# Altium schematic sheet 遥控.SchDoc
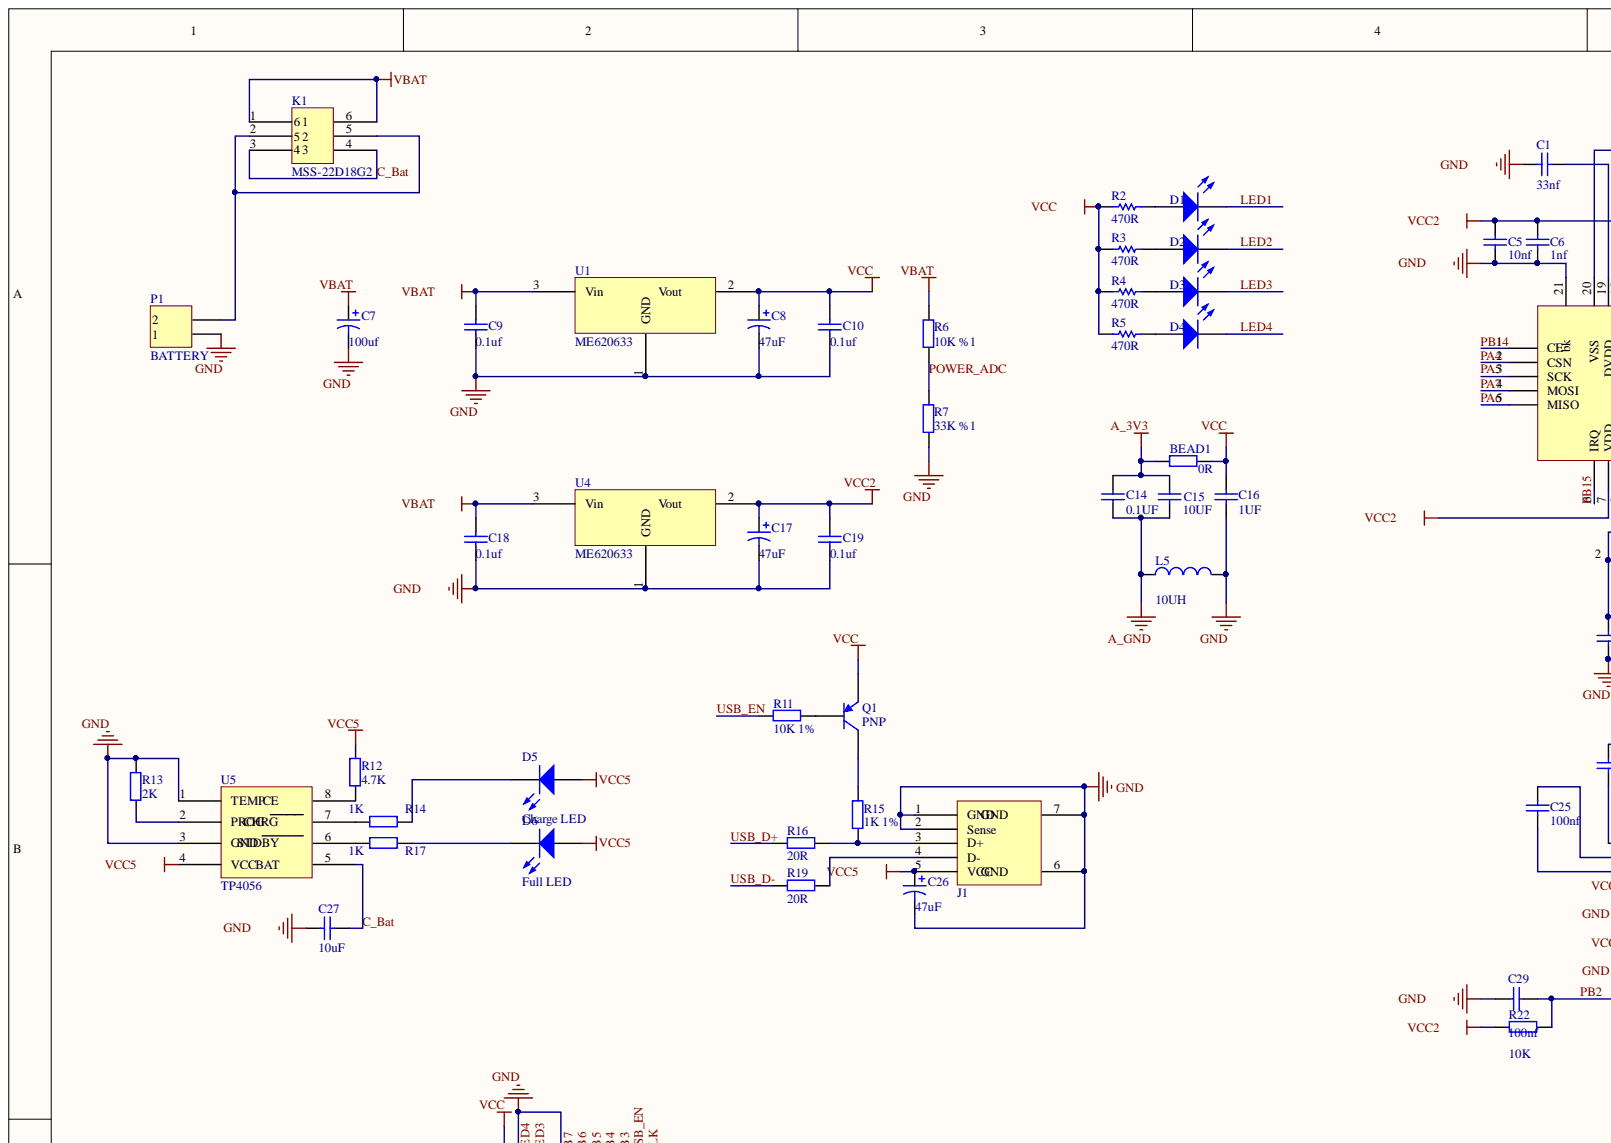
[diagram p0: 遥控.SchDoc, top left]
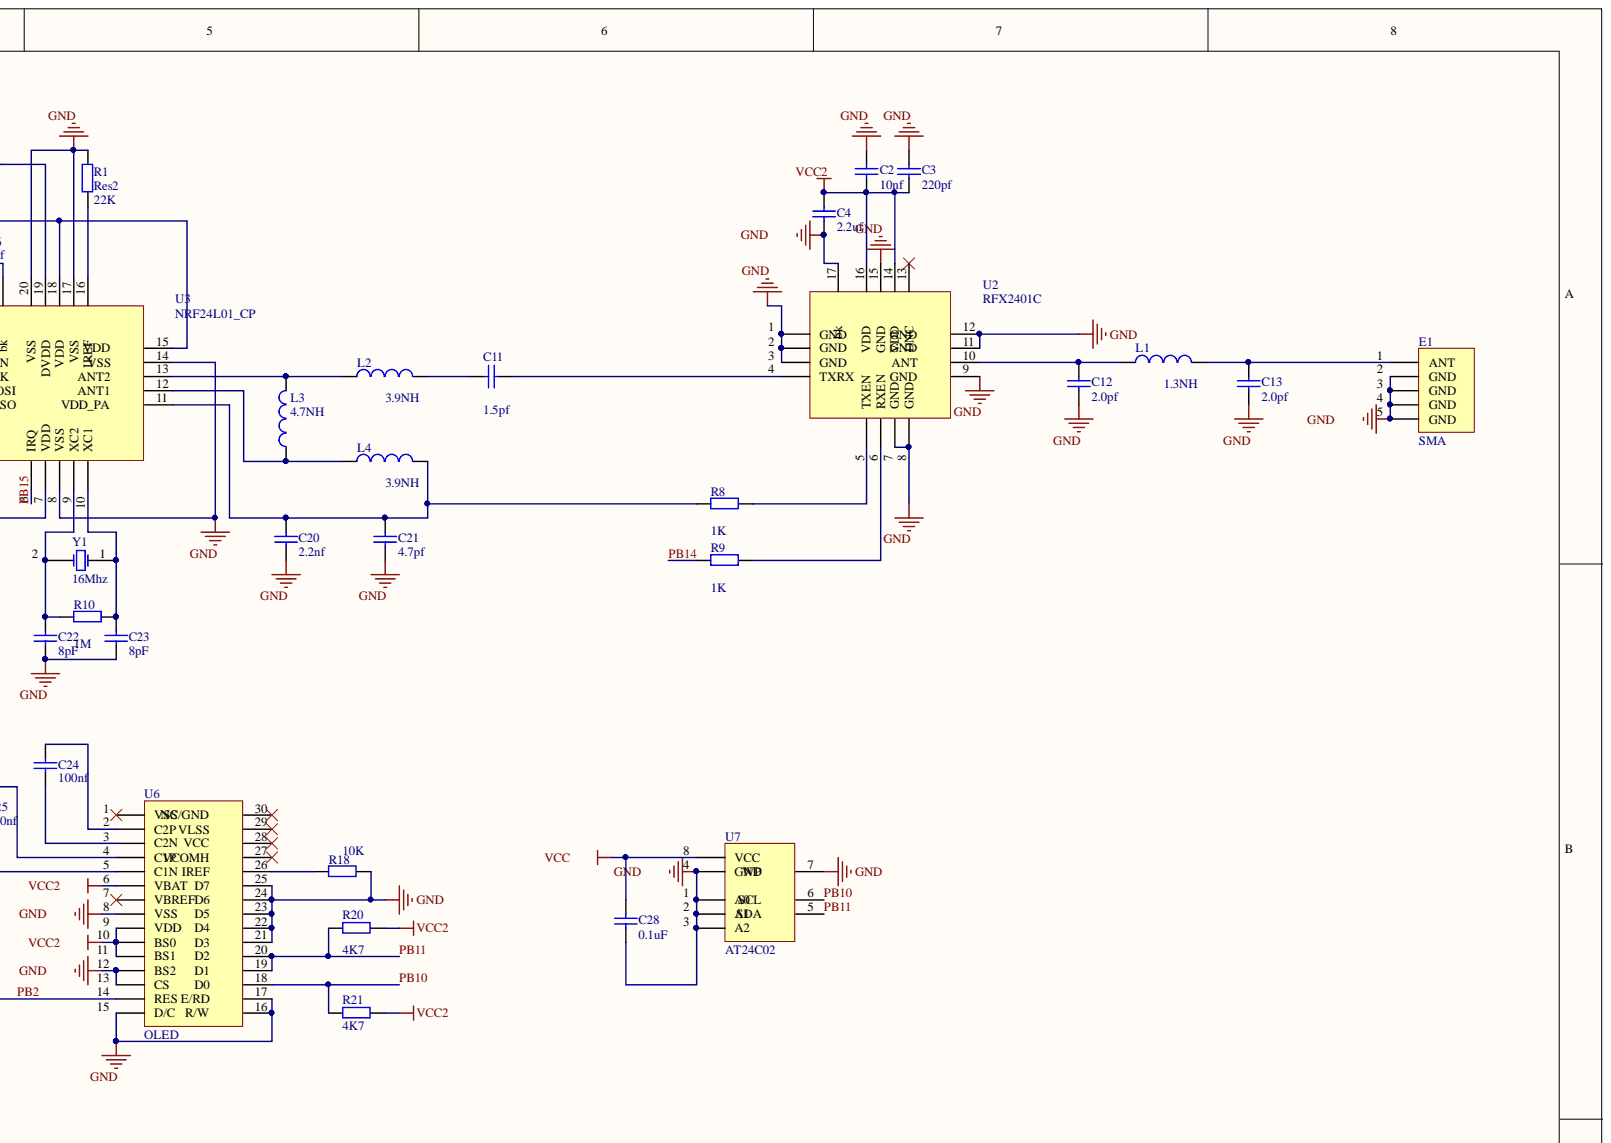
[diagram p1: 遥控.SchDoc, top right]
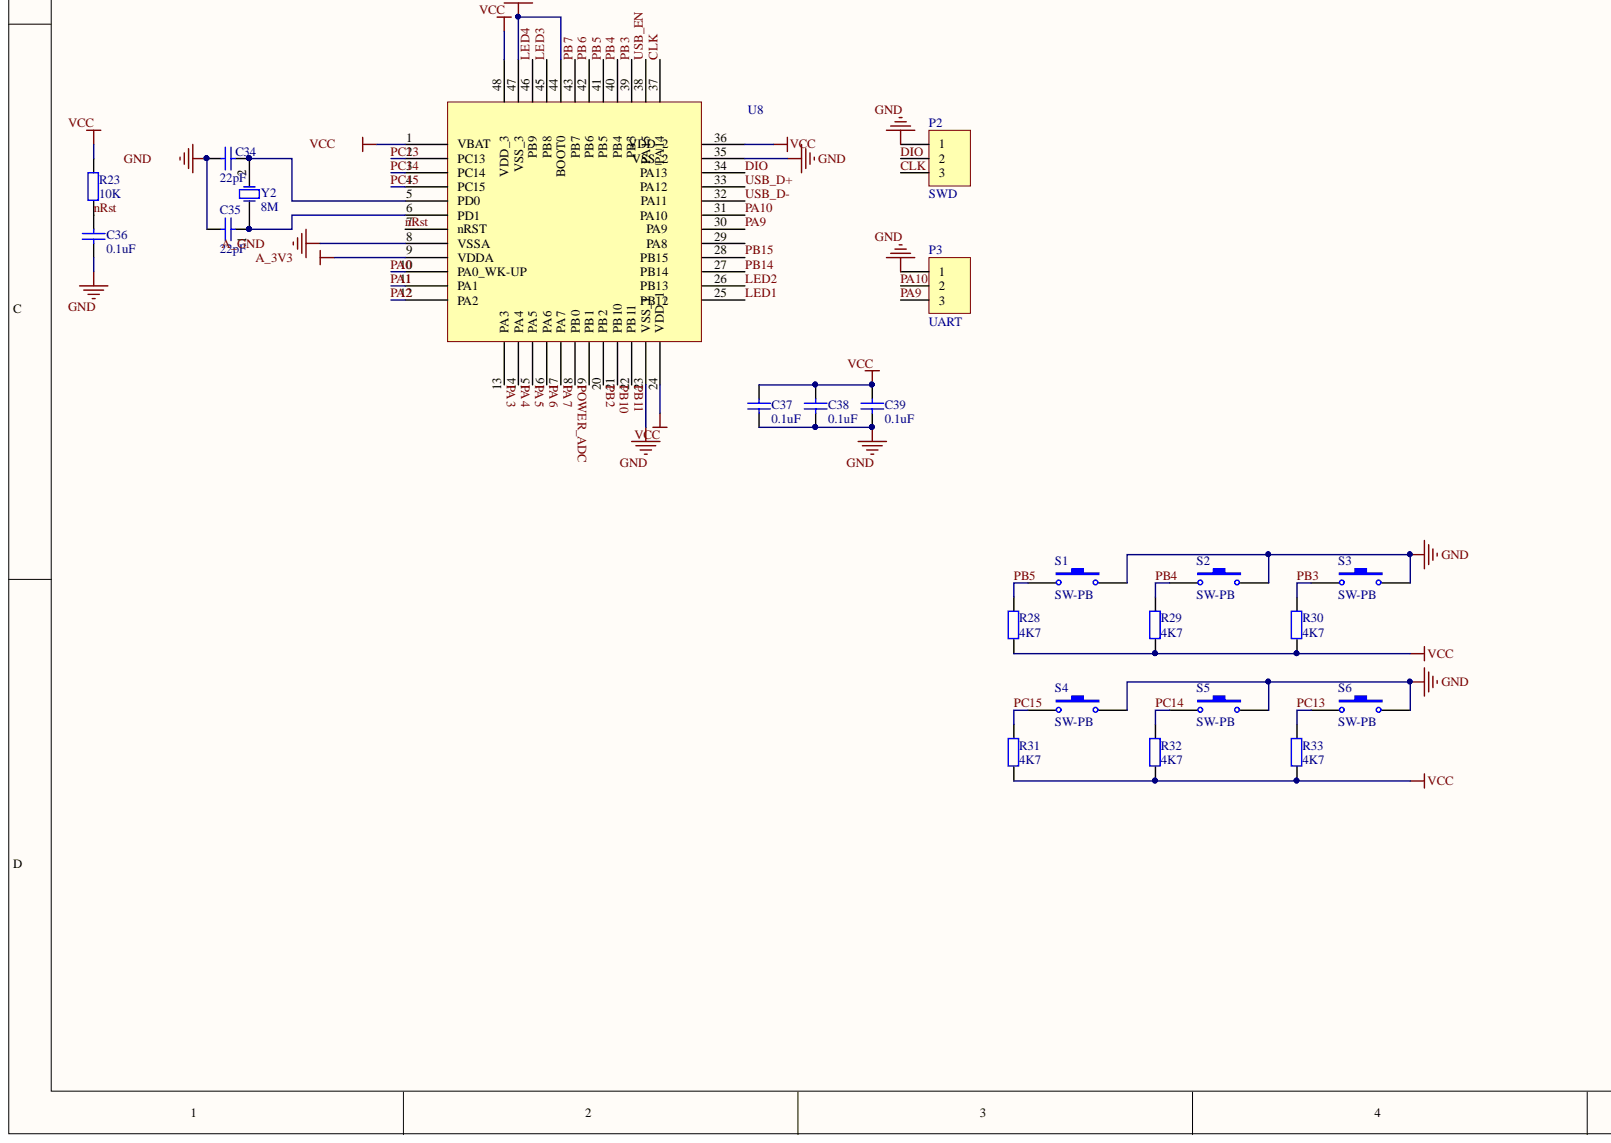
[diagram p2: 遥控.SchDoc, bottom left]
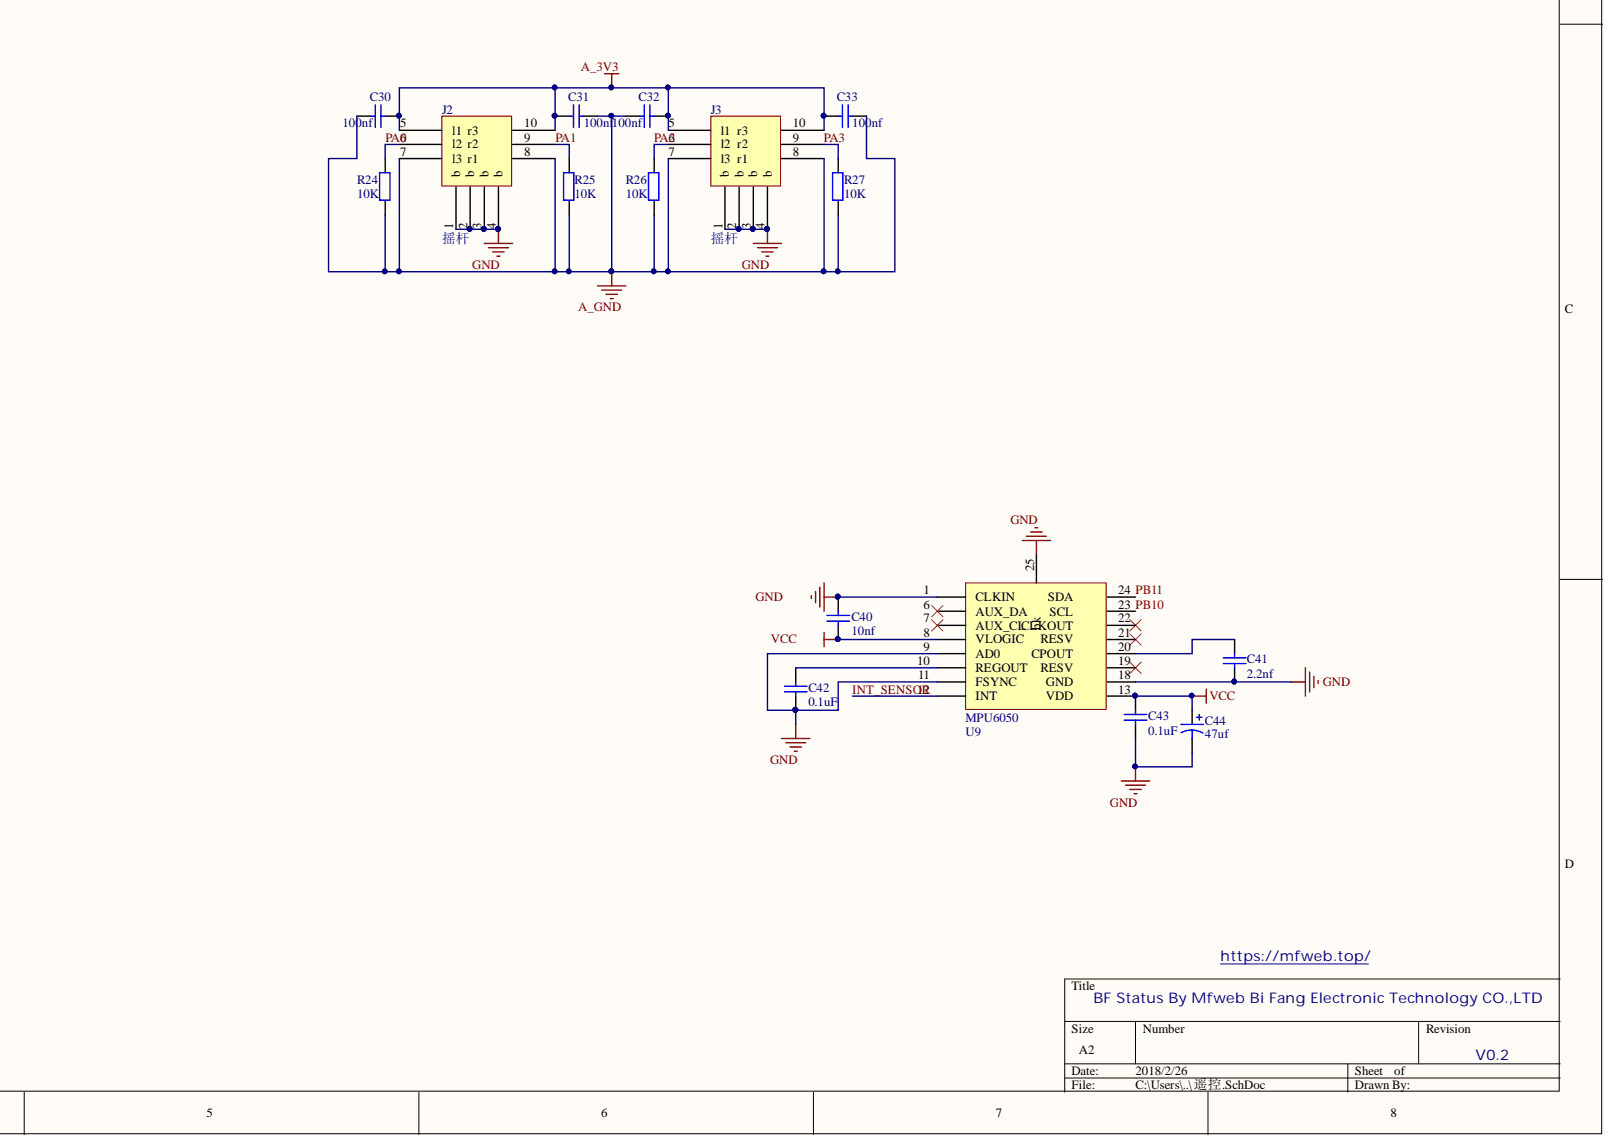
[diagram p3: 遥控.SchDoc, bottom right]

## Parts
BEAD1  value 0R  [0805]  (hidden comment: Res2)
C1  value 33nf  [0603]  (hidden comment: Cap)
C2  value 10nf  [0603]  (hidden comment: Cap)
C3  value 220pf  [0603]  (hidden comment: Cap)
C4  value 2.2uf  [0603]  (hidden comment: Cap)
C5  value 10nf  [0603]  (hidden comment: Cap)
C6  value 1nf  [0603]  (hidden comment: Cap)
C7  value 100uf  [1206]  (hidden comment: Cap Pol1)
C8  value 47uF  [0805]  (hidden comment: Cap Pol1)
C9  value 0.1uf  [0603]  (hidden comment: Cap)
C10  value 0.1uf  [0603]  (hidden comment: Cap)
C11  value 1.5pf  [0603]  (hidden comment: Cap)
C12  value 2.0pf  [0603]  (hidden comment: Cap)
C13  value 2.0pf  [0603]  (hidden comment: Cap)
C14  value 0.1UF  [0603]  (hidden comment: Cap)
C15  value 10UF  [0603]  (hidden comment: Cap)
C16  value 1UF  [0603]  (hidden comment: Cap)
C17  value 47uF  [0805]  (hidden comment: Cap Pol1)
C18  value 0.1uf  [0603]  (hidden comment: Cap)
C19  value 0.1uf  [0603]  (hidden comment: Cap)
C20  value 2.2nf  [0603]  (hidden comment: Cap)
C21  value 4.7pf  [0603]  (hidden comment: Cap)
C22  value 8pF  [0603]  (hidden comment: Cap)
C23  value 8pF  [0603]  (hidden comment: Cap)
C24  value 100nf  [0603]  (hidden comment: 贴片电容)
C25  value 100nf  [0603]  (hidden comment: 贴片电容)
C26  value 47uF  [0805]  (hidden comment: Cap Pol1)
C27  value 10uF  [0603]  (hidden comment: 贴片电容)
C28  value 0.1uF  [0603]  (hidden comment: Cap)
C29  value 100nf  [0603]  (hidden comment: 贴片电容)
C30  value 100nf  [0603]  (hidden comment: 贴片电容)
C31  value 100nf  [0603]  (hidden comment: 贴片电容)
C32  value 100nf  [0603]  (hidden comment: 贴片电容)
C33  value 100nf  [0603]  (hidden comment: 贴片电容)
C34  value 22pF  [0603]  (hidden comment: Cap)
C35  value 22pF  [0603]  (hidden comment: Cap)
C36  value 0.1uF  [0603]  (hidden comment: Cap)
C37  value 0.1uF  [0603]  (hidden comment: Cap)
C38  value 0.1uF  [0603]  (hidden comment: Cap)
C39  value 0.1uF  [0603]  (hidden comment: Cap)
C40  value 10nf  [0603]  (hidden comment: Cap)
C41  value 2.2nf  [0603]  (hidden comment: Cap)
C42  value 0.1uF  [0603]  (hidden comment: Cap)
C43  value 0.1uF  [0603]  (hidden comment: Cap)
C44  value 47uf  [0805]  (hidden comment: Cap Pol1)
D1  LED0  [0805]  (value not drawn)
D2  LED0  [0805]  (value not drawn)
D3  LED0  [0805]  (value not drawn)
D4  LED0  [0805]  (value not drawn)
D5  Charge LED  [0805]
D6  Full LED  [0805]
E1  SMA  [RF_SMA]
J1  MicroUsb  [Micro_USB]  (value not drawn)
J2  摇杆  [摇杆 - Th]
J3  摇杆  [摇杆 - duplicate]
K1  MSS-22D18G2  [MSS-22D18G2]
L1  value 1.3NH  [0603]  (hidden comment: Inductor)
L2  value 3.9NH  [0603]  (hidden comment: Inductor)
L3  value 4.7NH  [0603]  (hidden comment: Inductor)
L4  value 3.9NH  [0603]  (hidden comment: Inductor)
L5  value 10UH  [0603]  (hidden comment: Inductor)
P1  BATTERY  [电池焊接头2P]
P2  SWD  [1.27J*3]
P3  UART  [PH2.0X3]
Q1  PNP  [SOT-23B_N]
R1  Res2  value 22K  [0603]
R2  value 470R  [0603]  (hidden comment: Res1)
R3  value 470R  [0603]  (hidden comment: Res1)
R4  value 470R  [0603]  (hidden comment: Res1)
R5  value 470R  [0603]  (hidden comment: Res1)
R6  value 10K %1  [0603]  (hidden comment: Res2)
R7  value 33K %1  [0603]  (hidden comment: Res2)
R8  value 1K  [0603]  (hidden comment: Res2)
R9  value 1K  [0603]  (hidden comment: Res2)
R10  value 1M  [0603]  (hidden comment: Res2)
R11  value 10K 1%  [0603]  (hidden comment: Res2)
R12  value 4.7K  [0603]  (hidden comment: Res2)
R13  value 2K  [0603]  (hidden comment: Res2)
R14  value 1K  [0603]  (hidden comment: Res2)
R15  value 1K 1%  [0603]  (hidden comment: Res2)
R16  value 20R  [0603]  (hidden comment: Res2)
R17  value 1K  [0603]  (hidden comment: Res2)
R18  value 10K  [0603]  (hidden comment: 贴片电阻)
R19  value 20R  [0603]  (hidden comment: Res2)
R20  value 4K7  [0603]  (hidden comment: Res2)
R21  value 4K7  [0603]  (hidden comment: Res2)
R22  value 10K  [0603]  (hidden comment: 贴片电阻)
R23  value 10K  [0603]  (hidden comment: Res2)
R24  value 10K  [0603]  (hidden comment: 贴片电阻)
R25  value 10K  [0603]  (hidden comment: 贴片电阻)
R26  value 10K  [0603]  (hidden comment: 贴片电阻)
R27  value 10K  [0603]  (hidden comment: 贴片电阻)
R28  value 4K7  [0603]  (hidden comment: Res2)
R29  value 4K7  [0603]  (hidden comment: Res2)
R30  value 4K7  [0603]  (hidden comment: Res2)
R31  value 4K7  [0603]  (hidden comment: Res2)
R32  value 4K7  [0603]  (hidden comment: Res2)
R33  value 4K7  [0603]  (hidden comment: Res2)
S1  SW-PB  [两脚按键]
S2  SW-PB  [两脚按键]
S3  SW-PB  [两脚按键]
S4  SW-PB  [两脚按键]
S5  SW-PB  [两脚按键]
S6  SW-PB  [两脚按键]
U1  ME620633  [SOT-23]
U2  RFX2401C  [QFN16]
U3  NRF24L01_CP  [QFN20]
U4  ME620633  [SOT-23]
U5  TP4056  [SOP8_Body]
U6  OLED  [OLED]
U7  AT24C02  [SO-8]
U8  CBT6  [LQFP-48_N]  (value not drawn)
U9  MPU6050  [QFN24_MPU]
Y1  16Mhz  [3225四角有源晶振]
Y2  8M  [3225四角有源晶振]

## Nets
A_3V3: BEAD1.1 C14.2 C15.2 C30.1 C31.2 C32.2 C33.1 J2.5(l1) J2.10 J3.5(l1) J3.10 U8.9(VDDA)
A_GND: C14.1 C15.1 C30.2 C31.1 C32.1 C33.2 J2.7(l3) J2.8 J3.7(l3) J3.8 L5.1 R24.1 R25.1 R26.1 R27.1 U8.8(VSSA)
GND: C1.2 C2.1 C3.2 C4.1 C5.1 C6.1 C7.2 C8.2 C9.1 C10.1 C12.1 C13.1 C16.1 C17.2 C18.1 C19.1 C20.2 C21.2 C22.1 C23.1 C26.2 C27.1 C28.1 C29.1 C34.1 C35.1 C36.1 C37.1 C38.1 C39.1 C40.2 C41.1 C42.2 C43.1 C44.2 E1.2(GND) E1.3(GND) E1.4(GND) E1.5(GND) J1.1(GND) J1.2(Sense) J1.6 J1.7 J2.1(b) J2.2(b) J2.3(b) J2.4(b) J3.1(b) J3.2(b) J3.3(b) J3.4(b) L5.2 P1.1 P2.1 P3.1 R1.2 R7.1 R13.1 R18.2 S1.2 S2.2 S3.2 S4.2 S5.2 S6.2 U1.1 U2.1(GND) U2.2(GND) U2.3(GND) U2.7(GND) U2.8(GND) U2.9 U2.11 U2.12 U2.15 U2.17 U3.8(VSS) U3.14 U3.17 U3.20 U3.21 U4.1 U5.1(TEMP) U5.3(GND) U6.8(VSS) U6.12(BS2) U6.13(CS) U6.15(D/C) U6.16 U6.17 U6.21 U6.22 U6.23 U6.24 U6.25 U7.1(A0) U7.2(A1) U7.3(A2) U7.4(GND) U7.7 U8.23(VSS_1) U8.35 U8.44 U8.47 U9.1(CLKIN) U9.9(AD0) U9.11(FSYNC) U9.18 U9.25
VBAT: C7.1 C9.2 C18.2 K1.1 K1.6 R6.2 U1.3 U4.3
VCC: BEAD1.2 C8.1 C10.2 C16.2 C28.2 C37.2 C38.2 C39.2 C40.1 C43.2 C44.1 Q1.3 R2.1 R3.1 R4.1 R5.1 R23.2 R28.2 R29.2 R30.2 R31.2 R32.2 R33.2 U1.2 U7.8(VCC) U8.1(VBAT) U8.24(VDD_1) U8.36 U8.48 U9.8(VLOGIC) U9.13
VCC2: C2.2 C3.1 C4.2 C5.2 C6.2 C17.1 C19.2 R20.1 R21.1 R22.1 U2.14 U2.16 U3.7(VDD) U3.15 U3.18 U4.2 U6.6(VBAT) U6.9(VDD) U6.10(BS0) U6.11(BS1)
VCC5: C26.1 D5.1 D6.1 J1.5(VCC) R12.1 U5.4(VCC)
C_BAT: C27.2 K1.3 K1.4 U5.5
CLK: P2.3 U8.37
DIO: P2.2 U8.34
INT_SENSOR: U9.12(INT)
LED1: D1.2 U8.25
LED2: D2.2 U8.26
LED3: D3.2 U8.45
LED4: D4.2 U8.46
NRST: C36.2 R23.1 U8.7(nRST)
PA0: J2.6(l2) R24.2 U8.10(PA0_WK-UP)
PA1: J2.9 R25.2 U8.11(PA1)
PA2: J3.6(l2) R26.2 U8.12(PA2)
PA3: J3.9 R27.2 U8.13(PA3)
PA4: U3.2(CSN) U8.14(PA4)
PA5: U3.3(SCK) U8.15(PA5)
PA6: U3.5(MISO) U8.16(PA6)
PA7: U3.4(MOSI) U8.17(PA7)
PA9: P3.3 U8.30
PA10: P3.2 U8.31
PB2: C29.2 R22.2 U6.14 U8.20(PB2)
PB3: R30.1 S3.1 U8.39
PB4: R29.1 S2.1 U8.40
PB5: R28.1 S1.1 U8.41
PB6: U8.42
PB7: U8.43
PB10: R21.2 U6.18 U7.6 U8.21 U9.23
PB11: R20.2 U6.19 U6.20 U7.5 U8.22(PB11) U9.24
PB14: R9.1 U3.1(CE) U8.27
PB15: U3.6(IRQ) U8.28
PC13: R33.1 S6.1 U8.2(PC13)
PC14: R32.1 S5.1 U8.3(PC14)
PC15: R31.1 S4.1 U8.4(PC15)
POWER_ADC: R6.1 R7.2 U8.18
USB_D+: R16.1 U8.33
USB_D-: R19.1 U8.32
USB_EN: R11.2 U8.38
NetC1_1: C1.1 U3.19
NetC11_1: C11.1 L2.2
NetC11_2: C11.2 U2.4(TXRX)
NetC12_2: C12.2 L1.1 U2.10
NetC13_2: C13.2 E1.1(ANT) L1.2
NetC20_1: C20.1 C21.1 L4.2 R8.1 U3.11
NetC22_2: C22.2 R10.1 U3.9(XC2) Y1.2
NetC23_2: C23.2 R10.2 U3.10(XC1) Y1.1
NetC24_1: C24.1 U6.3(C2N)
NetC24_2: C24.2 U6.2
NetC25_1: C25.1 U6.5
NetC25_2: C25.2 U6.4(C1P)
NetC34_2: C34.2 U8.5(PD0) Y2.2
NetC35_2: C35.2 U8.6(PD1) Y2.1
NetC41_2: C41.2 U9.20
NetC42_1: C42.1 U9.10(REGOUT)
NetD1_1: D1.1 R2.2
NetD2_1: D2.1 R3.2
NetD3_1: D3.1 R4.2
NetD4_1: D4.1 R5.2
NetD5_2: D5.2 R14.2
NetD6_2: D6.2 R17.2
NetJ1_3: J1.3(D+) R15.1 R16.2
NetJ1_4: J1.4(D-) R19.2
NetK1_2: K1.2 K1.5 P1.2
NetL2_1: L2.1 L3.2 U3.13
NetL3_1: L3.1 L4.1 U3.12
NetQ1_1: Q1.1 R15.2
NetQ1_2: Q1.2 R11.1
NetR1_1: R1.1 U3.16
NetR8_2: R8.2 U2.5(TXEN)
NetR9_2: R9.2 U2.6(RXEN)
NetR12_2: R12.2 U5.8
NetR13_2: R13.2 U5.2(PROG)
NetR14_1: R14.1 U5.7
NetR17_1: R17.1 U5.6
NetR18_1: R18.1 U6.26

Not connected: U2 13; U6 1(VSS) 7 27 28 29 30; U8 19(PB1) 29; U9 6(AUX_DA) 7(AUX_CL) 19 21 22

## Library data of the parts
BEAD1 R1 R6 R7 R9 R11-R33 = Res2: Resistor; Component Kind: Standard; LatestRevisionDate: 17-Jul-2002; LatestRevisionNote: Re-released for DXP Platform.; Library Name: Miscellaneous Devices.IntLib; PackageReference: AXIAL-0.4; Published: 8-Jun-2000; Signal Integrity: Res; Simulation: RESISTOR; Publisher: Altium Limited
C1-C6 C9-C16 C18-C25 C27 C28 C30-C43 = Cap: Capacitor; Component Kind: Standard; LatestRevisionDate: 17-Jul-2002; LatestRevisionNote: Re-released for DXP Platform.; Library Name: Miscellaneous Devices.IntLib; PackageReference: RAD-0.3; Published: 8-Jun-2000; Signal Integrity: Cap; Simulation: CAP; Publisher: Altium Limited
  C34 C35 C37-C39: PackageDescription: Radial Cap, Thru-Hole; 2 Leads; 0.3 in Pin Spacing
C7 C8 C17 C26 C44 = Cap Pol1: Polarized Capacitor (Radial); Component Kind: Standard; LatestRevisionDate: 17-Jul-2002; LatestRevisionNote: Re-released for DXP Platform.; Library Name: Miscellaneous Devices.IntLib; PackageReference: RB7.6-15; Published: 8-Jun-2000; Signal Integrity: Cap Pol; Simulation: CAP; Publisher: Altium Limited
C29 = Cap: Capacitor; Value: 100nf; Component Kind: Standard; LatestRevisionDate: 17-Jul-2002; LatestRevisionNote: Re-released for DXP Platform.; Library Name: Miscellaneous Devices.IntLib; PackageReference: RAD-0.3; Published: 8-Jun-2000; Signal Integrity: Cap; Simulation: CAP; Publisher: Altium Limited
D1-D6 = LED0: Typical INFRARED GaAs LED; Component Kind: Standard; LatestRevisionDate: 08-Jul-2005; LatestRevisionNote: Mech Layer 1 removed.; Library Name: Miscellaneous Devices.IntLib; PackageReference: LED-0; Published: 8-Jun-2000; Simulation: LED0; Publisher: Altium Limited
  D5 D6: PackageDescription: LED; 2 Leads
L1-L5 = Inductor: Code_IEC: 1005; Code_JEITA: 0402; Component Kind: Standard; Description: Inductor; LatestRevisionDate: 17-Jul-2002; LatestRevisionNote: Re-released for DXP Platform.; Library Name: Miscellaneous Devices.IntLib; PackageReference: 0402-A; Published: 8-Jun-2000; Signal Integrity: Inductor; Simulation: INDUCTOR; Publisher: Altium Limited
P1 = Header 2: Header, 2-Pin; Component Kind: Standard; LatestRevisionDate: 17-Jul-2002; LatestRevisionNote: Re-released for DXP Platform.; Library Name: Miscellaneous Connectors.IntLib; Signal Integrity: Connector; Publisher: Altium Limited
P2 P3 = Header 3: Header, 3-Pin; Component Kind: Standard; LatestRevisionDate: 17-Jul-2002; LatestRevisionNote: Re-released for DXP Platform.; Library Name: Miscellaneous Connectors.IntLib; Signal Integrity: Connector; Publisher: Altium Limited
Q1 = PNP: PNP Bipolar Transistor; Component Kind: Standard; LatestRevisionDate: 29-May-2009; LatestRevisionNote: IPC-7351 Footprint Added.; Library Name: Miscellaneous Devices.IntLib; PackageDescription: 3-Pin SOT-23 Package 0.95 mm Pitch, 2.4 mm Lead Span; PackageReference: SOT-23B; PackageVersion: Aug-1999; Published: 8-Jun-2000; Signal Integrity: PNP; Simulation: PNP; Publisher: Altium Limited
R2-R5 = Res1: Resistor; Component Kind: Standard; LatestRevisionDate: 17-Jul-2002; LatestRevisionNote: Re-released for DXP Platform.; Library Name: Miscellaneous Devices.IntLib; PackageReference: AXIAL-0.3; Published: 8-Jun-2000; Signal Integrity: Res; Simulation: RESISTOR; Publisher: Altium Limited
R8 = Res2: Resistor; Value: 1K; Component Kind: Standard; LatestRevisionDate: 17-Jul-2002; LatestRevisionNote: Re-released for DXP Platform.; Library Name: Miscellaneous Devices.IntLib; PackageReference: AXIAL-0.4; Published: 8-Jun-2000; Signal Integrity: Res; Simulation: RESISTOR; Publisher: Altium Limited
R10 = Res2: Resistor; Value: 1M; Component Kind: Standard; LatestRevisionDate: 17-Jul-2002; LatestRevisionNote: Re-released for DXP Platform.; Library Name: Miscellaneous Devices.IntLib; PackageReference: AXIAL-0.4; Published: 8-Jun-2000; Signal Integrity: Res; Simulation: RESISTOR; Publisher: Altium Limited
S1-S6 = SW-PB: Switch; Component Kind: Standard; LatestRevisionDate: 17-Jul-2002; LatestRevisionNote: Re-released for DXP Platform.; Library Name: Miscellaneous Devices.IntLib; PackageDescription: Switch; 2 Leads; PackageReference: SPST-2; Published: 24-Mar-1999; Publisher: Altium Limited
Y1 Y2 = XTAL: Crystal Oscillator; Component Kind: Standard; LatestRevisionDate: 17-Jul-2002; LatestRevisionNote: Re-released for DXP Platform.; Library Name: Miscellaneous Devices.IntLib; PackageReference: R38; Published: 8-Jun-2000; Simulation: XTAL; Publisher: Altium Limited
  Y2: PackageDescription: Crystal, Thru-Hole; 2 Leads; Body 3.1 x 8.2 mm (Dia.xH)
E1 = SMA: Component Kind: Standard
J1 = MicroUsb: Component Kind: Standard; Library Name: Lib.SchLib
J2 J3 = 摇杆: Component Kind: Standard; Library Name: sc.SchLib
K1 = MSS-22D18G2: Component Kind: Standard; Library Name: 库.SchLib
U1 U4 = ME620633: Component Kind: Standard; Library Name: Schlib1.SchLib
U2 = RFX2401C: Component Kind: Standard; Library Name: 库.SchLib
U3 = NRF24L01_CP: Component Kind: Standard; Library Name: 库.SchLib
U5 = TP4056: Component Kind: Standard; Library Name: 库.SchLib
U6 = OLED: Component Kind: Standard; Library Name: 库.SchLib
U7 = AT24C02: Component Kind: Standard
U8 = CBT6: Component Kind: Standard; Library Name: Lib.SchLib
U9 = MPU6050: Component Kind: Standard; Library Name: 库.SchLib
